# Altium schematic sheet Interface Board.SchDoc
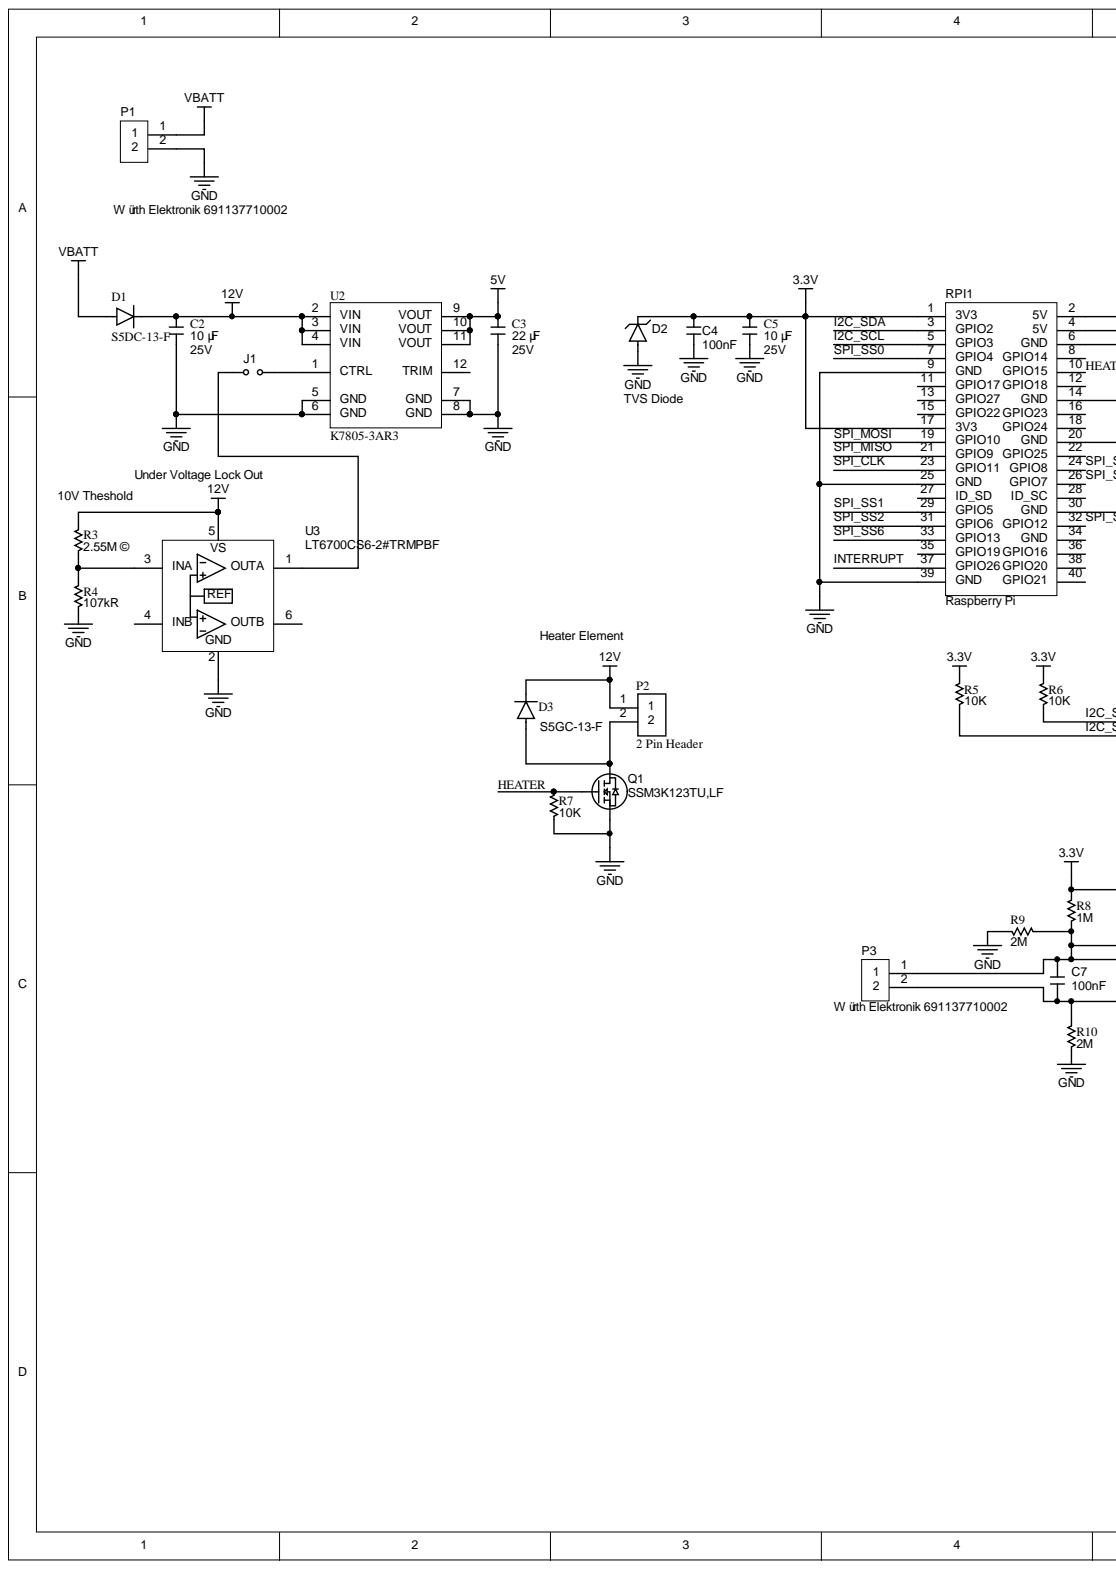
[diagram p0: Interface Board.SchDoc, left]
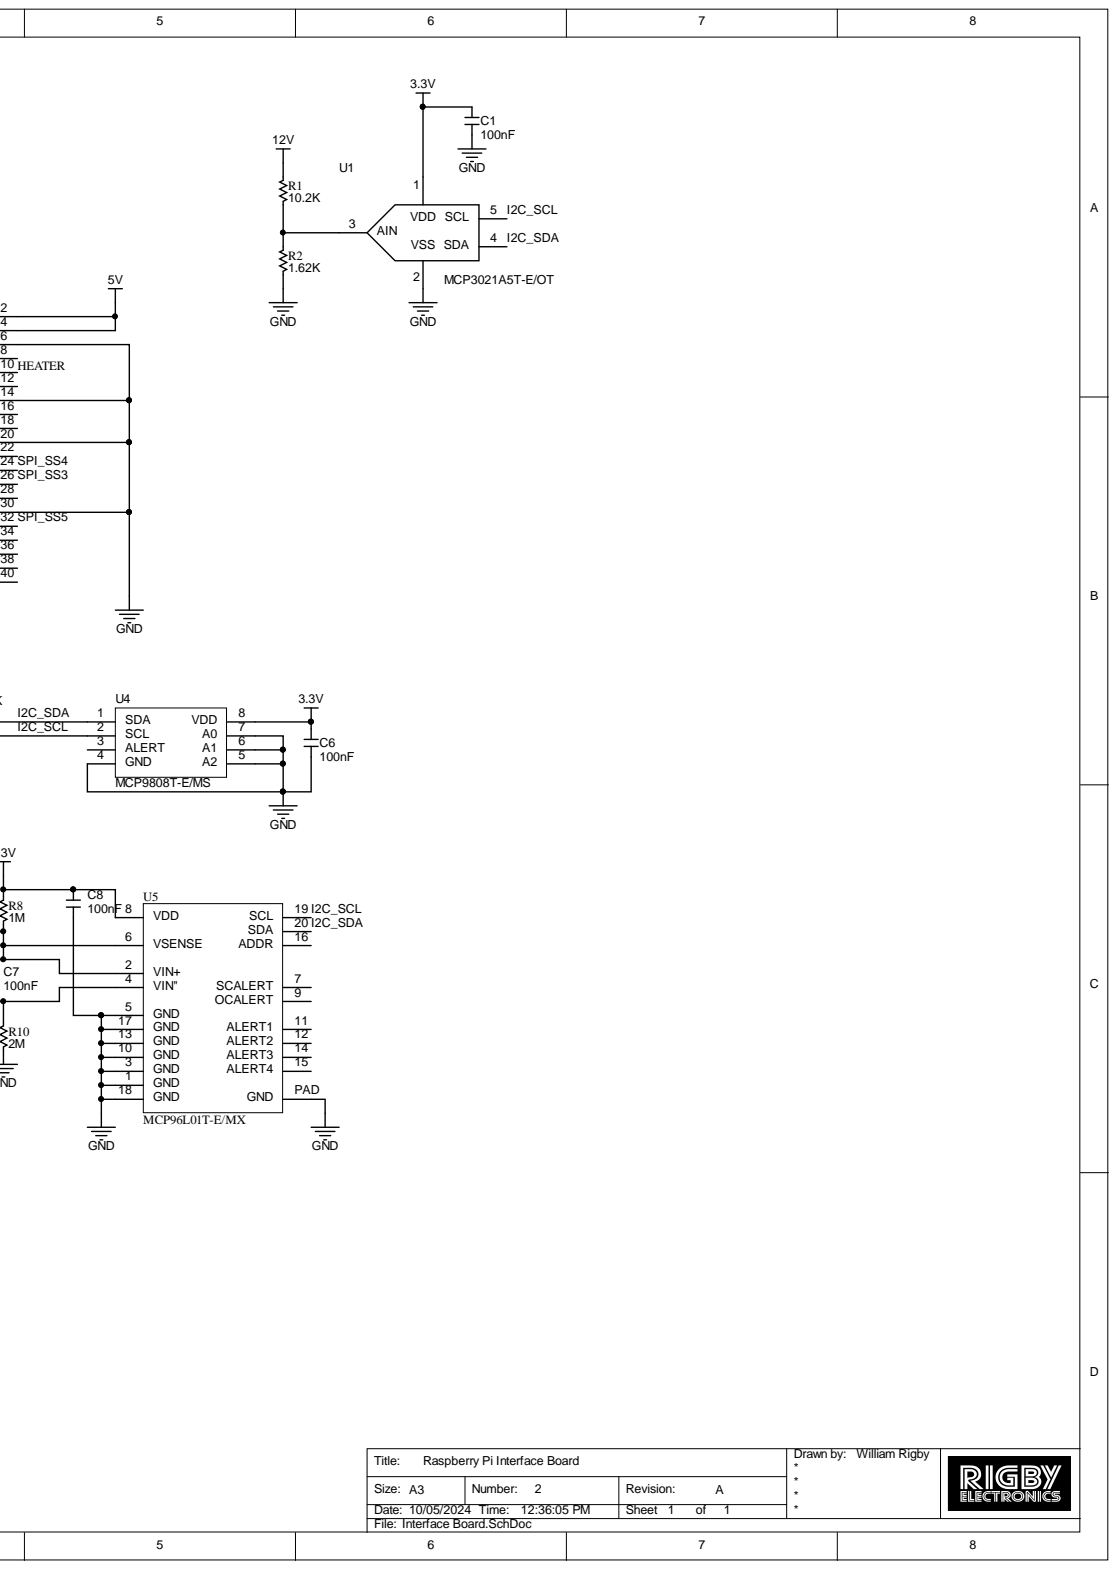
[diagram p1: Interface Board.SchDoc, right]

## Parts
C1  100nF  [C0805]
C2  Capacitance: 10µF  Voltage Rating: 25V  [C0805]  (hidden comment: F)
C3  Capacitance: 22µF  Voltage Rating: 25V  [C0805]  (hidden comment: F)
C4  100nF  [C0805]
C5  Capacitance: 10µF  Voltage Rating: 25V  [C0805]  (hidden comment: F)
C6  100nF  [C0805]
C7  100nF  [C0805]
C8  100nF  [C0805]
D1  S5DC-13-F  [SMC]
D2  TVS Diode  [SP4020-01FTG-C]
D3  S5GC-13-F  [SMC]
J1  Jumper 2 Pin  [Jumper 2 Pins]  (value not drawn)  (jumper)
P1  Würth Elektronik 691137710002  [Würth Elektronik 691137710002]
P2  2 Pin Header  [S2B-XH-A(LF)(SN)]
P3  Würth Elektronik 691137710002  Manufacturer: Würth Elektronik  Manufacturer Part Number: 691137710002  [Würth Elektronik 691137710002]
Q1  SSM3K123TU,LF  [SSM3K123TU,LF]
R1  Resistance: 10.2K  [R0805]  (hidden comment: 10.2kΩ)
R2  Resistance: 1.62K  [R0805]  (hidden comment: 1620Ω)
R3  107kΩ  [R0805]  (value not drawn)
R4  107kΩ  Resistance: 107kR  [R0805]
R5  Resistance: 10K  [R0805]  (hidden comment: 330Ω)
R6  Resistance: 10K  [R0805]  (hidden comment: 330Ω)
R7  Resistance: 10K  [R0805]  (hidden comment: 330Ω)
R8  Resistance: 1M  [R0805]  (hidden comment: 330Ω)
R9  Resistance: 2M  [R0805]  (hidden comment: 330Ω)
R10  Resistance: 2M  [R0805]  (hidden comment: 330Ω)
RPI1  Raspberry Pi  [Header 40]
U1  MCP3021A5T-E/OT  [MCP3021A5T-E/OT]
U2  K7805-3AR3  [K7805-3AR3]
U3  LT6700CS6-2#TRMPBF  [LT6700CS6-2#TRMPBF]
U4  MCP9808T-E/MS  [MCP9808T-E/MS]
U5  MCP96L01T-E/MX  [MCP96L01T-E/MX]

## Nets
3.3V: C1.1 C4.1 C5.1 C6.1 C8.1 D2.1 R5.2 R6.2 R8.2 RPI1.1(3V3) RPI1.17(3V3) U1.1 U4.8(VDD) U5.8(VDD)
5V: C3.1 RPI1.2(5V) RPI1.4(5V) U2.9(VOUT) U2.10(VOUT) U2.11(VOUT)
12V: C2.1 D1.1 D3.1 P2.1 R1.2 R3.1 U2.2(VIN) U2.3(VIN) U2.4(VIN) U3.5(VS)
GND: C1.2 C2.2 C3.2 C4.2 C5.2 C6.2 C8.2 D2.2 P1.2 Q1.2 R2.1 R4.2 R7.1 R9.2 R10.2 RPI1.6(GND) RPI1.9(GND) RPI1.14(GND) RPI1.20(GND) RPI1.25(GND) RPI1.30(GND) RPI1.39(GND) U1.2 U2.5(GND) U2.6(GND) U2.7(GND) U2.8(GND) U3.2 U4.4(GND) U4.5(A2) U4.6(A1) U4.7(A0) U5.1(GND) U5.3(GND) U5.5(GND) U5.10(GND) U5.13(GND) U5.17(GND) U5.18(GND) U5.PAD(GND)
VBATT: D1.2 P1.1
HEATER: Q1.1 R7.2 RPI1.10(GPIO15)
I2C_SCL: R5.1 RPI1.5(GPIO3) U1.5(SCL) U4.2(SCL) U5.19(SCL)
I2C_SDA: R6.1 RPI1.3(GPIO2) U1.4(SDA) U4.1(SDA) U5.20(SDA)
INTERRUPT: RPI1.37(GPIO26)
SPI_CLK: RPI1.23(GPIO11)
SPI_MISO: RPI1.21(GPIO9)
SPI_MOSI: RPI1.19(GPIO10)
SPI_SS0: RPI1.7(GPIO4)
SPI_SS1: RPI1.29(GPIO5)
SPI_SS2: RPI1.31(GPIO6)
SPI_SS3: RPI1.26(GPIO7)
SPI_SS4: RPI1.24(GPIO8)
SPI_SS5: RPI1.32(GPIO12)
SPI_SS6: RPI1.33(GPIO13)
NetC7_1: C7.1 P3.1 R8.1 R9.1 U5.2(VIN+) U5.6(VSENSE)
NetC7_2: C7.2 P3.2 R10.1 U5.4(VIN")
NetD3_2: D3.2 P2.2 Q1.3
NetJ1_1: J1.1 U2.1(CTRL)
NetJ1_2: J1.2 U3.1(OUTA)
NetR1_1: R1.1 R2.2 U1.3(AIN)
NetR3_2: R3.2 R4.1 U3.3(INA)

Not connected: RPI1 8(GPIO14) 11(GPIO17) 12(GPIO18) 13(GPIO27) 15(GPIO22) 16(GPIO23) 18(GPIO24) 22(GPIO25) 27(ID_SD) 28(ID_SC) 34(GND) 35(GPIO19) 36(GPIO16) 38(GPIO20) 40(GPIO21); U2 12(TRIM); U3 4(INB) 6(OUTB); U4 3(ALERT); U5 7(SCALERT) 9(OCALERT) 11(ALERT1) 12(ALERT2) 14(ALERT3) 15(ALERT4) 16(ADDR)

## Library data of the parts
C1 C4 C6-C8 = Capacitor: Manufacturer: YAGEO; Manufacturer Part Number: CC0805KRX7R9BB104; Temperature Coefficient: X7R; Tolerance: ±10%; Voltage Rating: 50V; Capacitance: 100nF; Component Kind: Standard; Description: Capacitor; Supplier: Digi-Key Electronics; Supplier Part Number: 311-1140-1-ND; Library Name: Rigby Electronics Schematic Library.SchLib
C2 C5 = Capacitor: Manufacturer: Samsung Electro-Mechanics; Manufacturer Part Number: CL21A106KAYNNNG; Component Kind: Standard; Description: Capacitor; Price: 0.28; Supplier: Digi-Key Electronics; Supplier Part Number: 1276-6454-1-ND; Library Name: Rigby Electronics Schematic Library.SchLib
C3 = Capacitor: Manufacturer: Samsung Electro-Mechanics; Manufacturer Part Number: CL21A226MAYNNNE; Component Kind: Standard; Description: Capacitor; Price: 0.39; Supplier: Digi-Key Electronics; Supplier Part Number: 1276-CL21A226MAYNNNECT-ND; Library Name: Rigby Electronics Schematic Library.SchLib
D1 = S5DC-13-F: Diode Standard 200 V 5A Surface Mount SMC; Manufacturer: Diodes Incorporated; Reverse Protection Voltage: 200V; Component Kind: Standard; Current Rating: 5A; Manufacturer Part Number: S5DC-13-F; Supplier: Digi-Key Electronics; Supplier Part Number: S5DC-FDICT-ND; Library Name: Rigby Electronics Schematic Library.SchLib
D2 = Diode TVS: Manufacturer: Littelfuse Inc.; Component Kind: Standard; Description: TVS Diode; Manufacturer Part Number: SP4020-01FTG-C; Supplier: Digi-Key Electronics; Supplier Part Number: F6271CT-ND; Library Name: Rigby Electronics Schematic Library.SchLib
D3 = Diode: Manufacturer: Diodes Incorporated; Current Rating: 5A; Voltage: 400V; Component Kind: Standard; Description: Diode; Manufacturer Part Number: S5GC-13-F; Supplier: Digi-Key Electronics; Supplier Part Number: S5GC-FDICT-ND; Library Name: Rigby Electronics Schematic Library.SchLib
J1 = Jumper 2 Pin: 2 Pin Jumper; Library Name: Rigby Electronics Schematic Library.SchLib
P1 P3 = Würth Elektronik 691137710002: 2 Position Wire to Board Terminal Block Horizontal with Board 0.197" (5.00mm) Through Hole; Current Rating: 16A; Positions: 2; Voltage Rating: 300V; Wire Gauge: 12-30AWG; Component Kind: Standard; Supplier: Digi-Key Electronics; Supplier Part Number: 732-10955-ND; Library Name: Rigby Electronics Schematic Library.SchLib
  P1: Manufacturer: Würth Elektronik; Manufacturer Part Number: 691137710002
P2 = Header 2: Component Kind: Standard; Description: 2 Pin Header; Library Name: Rigby Electronics Schematic Library.SchLib
Q1 = SSM3K123TU,LF: N-Channel 20 V 4.2A (Ta) 500mW (Ta) Surface Mount UFM; Manufacturer: Toshiba Semiconductor and Storage; Component Kind: Standard; Manufactuer Part Number: SSM3K123TU,LF; Supplier: Digi-Key Electronics; Supplier Part Number: SSM3K123TULFCT-ND; Library Name: Rigby Electronics Schematic Library.SchLib
R1 = Resistor: Standard Resistor; Manufacturer: YAGEO; Manufacturer Part Number: RC0805FR-0710K2L; Power Rating: 1/8W; Component Kind: Standard; Price: 0.16; Supplier: Digi-Key Electronics; Supplier Part Number: 311-10.2KCRCT-ND; Library Name: Rigby Electronics Schematic Library.SchLib
R2 = Resistor: Standard Resistor; Manufacturer: YAGEO; Manufacturer Part Number: RC0805FR-071K62L; Power Rating: 1/8W; Component Kind: Standard; Price: 0.16; Supplier: Digi-Key Electronics; Supplier Part Number: 311-1.62KCRCT-ND; Library Name: Rigby Electronics Schematic Library.SchLib
R3 = Resistor: Standard Resistor; Manufacturer: YAGEO; Manufacturer Part Number: RC0805FR-072M55L; Power Rating: 0.125W; Resistance: 2.55MΩ; Component Kind: Standard; Price: 0.16; Supplier: Digi-Key Electronics; Supplier Part Number: 311-2.55MCRCT-ND; Library Name: Rigby Electronics Schematic Library.SchLib
R4 = Resistor: Standard Resistor; Manufacturer: YAGEO; Manufacturer Part Number: RC0805FR-07107KL; Power Rating: 0.125W; Component Kind: Standard; Price: 0.16; Supplier: Digi-Key Electronics; Supplier Part Number: 311-107KCRCT-ND; Library Name: Rigby Electronics Schematic Library.SchLib
R5-R7 = Resistor: Standard Resistor; Manufacturer: YAGEO; Manufacturer Part Number: RC0805JR-0710KL; Power Rating: 1/8W; Component Kind: Standard; Price: 0.16; Supplier: Digi-Key Electronics; Supplier Part Number: 311-10KARCT-ND; Library Name: Rigby Electronics Schematic Library.SchLib
R8 = Resistor: Standard Resistor; Manufacturer: Panasonic Electronic Components; Manufacturer Part Number: ERA-6AEB105V; Power Rating: 1/8W; Component Kind: Standard; Price: 0.16; Supplier: Digi-Key Electronics; Supplier Part Number: P1MDACT-ND; Library Name: Rigby Electronics Schematic Library.SchLib
R9 R10 = Resistor: Standard Resistor; Manufacturer: Vishay Dale; Manufacturer Part Number: CRCW08052M00FKECC; Power Rating: 1/8W; Component Kind: Standard; Price: 0.16; Supplier: Digi-Key Electronics; Supplier Part Number: 541-4129-1-ND; Library Name: Rigby Electronics Schematic Library.SchLib
RPI1 = Raspberry Pi 40 Pin Header: Component Kind: Standard; Description: Raspberry Pi 40 Pin Header; Library Name: Rigby Electronics Schematic Library.SchLib
U1 = MCP3021A5T-E/OT: 10 Bit Analog to Digital Converter 1 Input 1 SAR SOT-23-5; Manufacturer: Microchip Technology; Component Kind: Standard; Manufacturer Part Number: MCP3021A5T-E/OT; Supplier: Digi-Key Electronics; Supplier Part Number: MCP3021A5T-E/OTCT-ND; Library Name: Rigby Electronics Schematic Library.SchLib
U2 = K7805-3AR3: Non-Isolated PoL Module DC DC Converter 1 Output 5V 3A 8V - 36V Input; Manufacturer: Mornsun America, LLC; Power: 15W; Voltage Input: 8-36V; Component Kind: Standard; Manufacturer Part Number: K7805-3AR3; Supplier: Digi-Key Electronics; Supplier Part Number: 2725-K7805-3AR3-ND; Voltage Output: 5V; Library Name: Rigby Electronics Schematic Library.SchLib
U3 = LT6700CS6-2#TRMPBF: Comparator with Voltage Reference Open-Collector TSOT-23-6; Manufacturer: Analog Devices Inc.; Component Kind: Standard; Manufacturer Part Number: LT6700CS6-2#TRMPBF; Supplier: Digi-Key Electronics; Supplier Part Number: 505-LT6700CS6-2#TRMPBFCT-ND; Library Name: Rigby Electronics Schematic Library.SchLib
U4 = MCP9808T-E/MS: Temperature Sensor Digital, Local -40°C ~ 125°C 12 b 8-MSOP; Manufacturer: Microchip Technology; Component Kind: Standard; Manufacturer Part Number: MCP9808T-E/MS; Supplier: Digi-Key Electronics; Supplier Part Number: MCP9808T-E/MSCT-ND; Library Name: Rigby Electronics Schematic Library.SchLib
U5 = MCP96L01T-E/MX: Thermocouple EMF to Temperature Converter,  ±1.5°C Maximum Accuracy; Manufacturer: Microchip Technology; Component Kind: Standard; Manufacturer Part Number: MCP96L01T-E/MX; Supplier: Digi-Key Electronics; Supplier Part Number: 150-MCP96L01T-E/MXCT-ND; Library Name: Rigby Electronics Schematic Library.SchLib
